# Altium schematic sheet mvp.schdoc
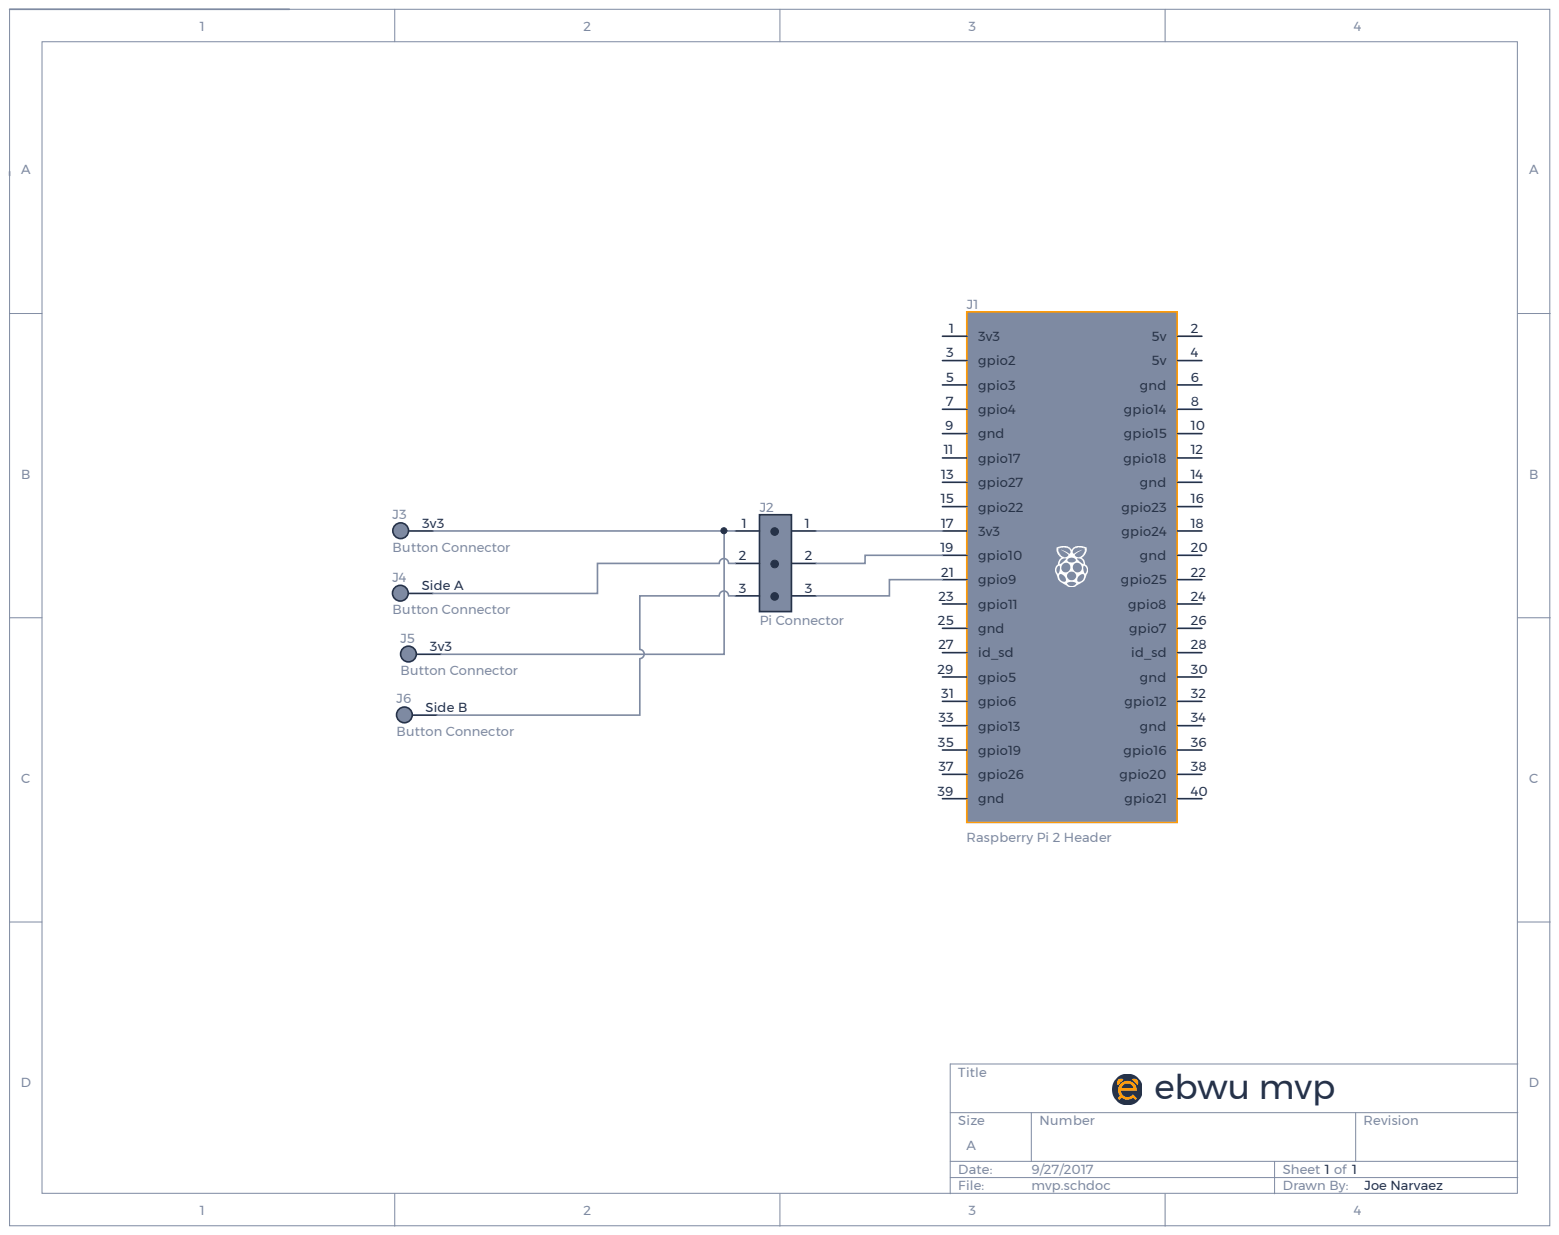
[diagram p0: mvp.schdoc]

## Parts
J1  Raspberry Pi 2 Header
J2  Pi Connector
J3  Button Connector
J4  Button Connector
J5  Button Connector
J6  Button Connector

## Nets
NetJ1_17: J1.17(3v3) J2.1
NetJ1_19: J1.19(gpio10) J2.2
NetJ1_21: J1.21(gpio9) J2.3
NetJ2_1: J2.1 J3.3v3 J5.3v3
NetJ2_2: J2.2 J4.Side A
NetJ2_3: J2.3 J6.Side B
N00001:
N00002:

Not connected: J1 1(3v3) 2(5v) 3(gpio2) 4(5v) 5(gpio3) 6(gnd) 7(gpio4) 8(gpio14) 9(gnd) 10(gpio15) 11(gpio17) 12(gpio18) 13(gpio27) 14(gnd) 15(gpio22) 16(gpio23) 18(gpio24) 20(gnd) 22(gpio25) 23(gpio11) 24(gpio8) 25(gnd) 26(gpio7) 27(id_sd) 28(id_sd) 29(gpio5) 30(gnd) 31(gpio6) 32(gpio12) 33(gpio13) 34(gnd) 35(gpio19) 36(gpio16) 37(gpio26) 38(gpio20) 39(gnd) 40(gpio21)

## Library data of the parts
J1 = CMP-mgln2i9j9qi02fyepybh-2: Raspberry Pi 2; Component Kind: Standard; CustomComponentID: mgln2i9j9qi02fyepybh; Library Name: FavoritesLibrary
J2 = CMP-1b59d2577c66c49e-9: Plug; wire-board; female; PIN: 3; w/o terminals; 2.5mm; for cable; Manufacturer Part Number: EHR-3; Lead-Free Status: Lead Free; Number of Contacts: 3; Pin Pitch: 2.50 mm; Color: White; Component Kind: Standard; Gender: Female; Housing Color: White; Housing Material: Nylon; Library Name: FavoritesLibrary; Number of Positions: 3; Number of Rows: 1; OctopartID: 1b59d2577c66c49e; Octopart URL: http://octopart.com/ehr-3-jst-279129; Packaging: Bulk; REACH SVHC Compliance: No SVHC; RoHS: Compliant; Size-Height: 7.10 mm; Size-Length: 9.50 mm; Size-Width: 3.80 mm
J3-J6 = CMP-cr5ncra6jj4sfh1kbt2y-1: Metal  Button Connector; Component Kind: Standard; CustomComponentID: cr5ncra6jj4sfh1kbt2y; Library Name: CommunityVault
